# Altium schematic sheet Keyfinder_Alert.SchDoc
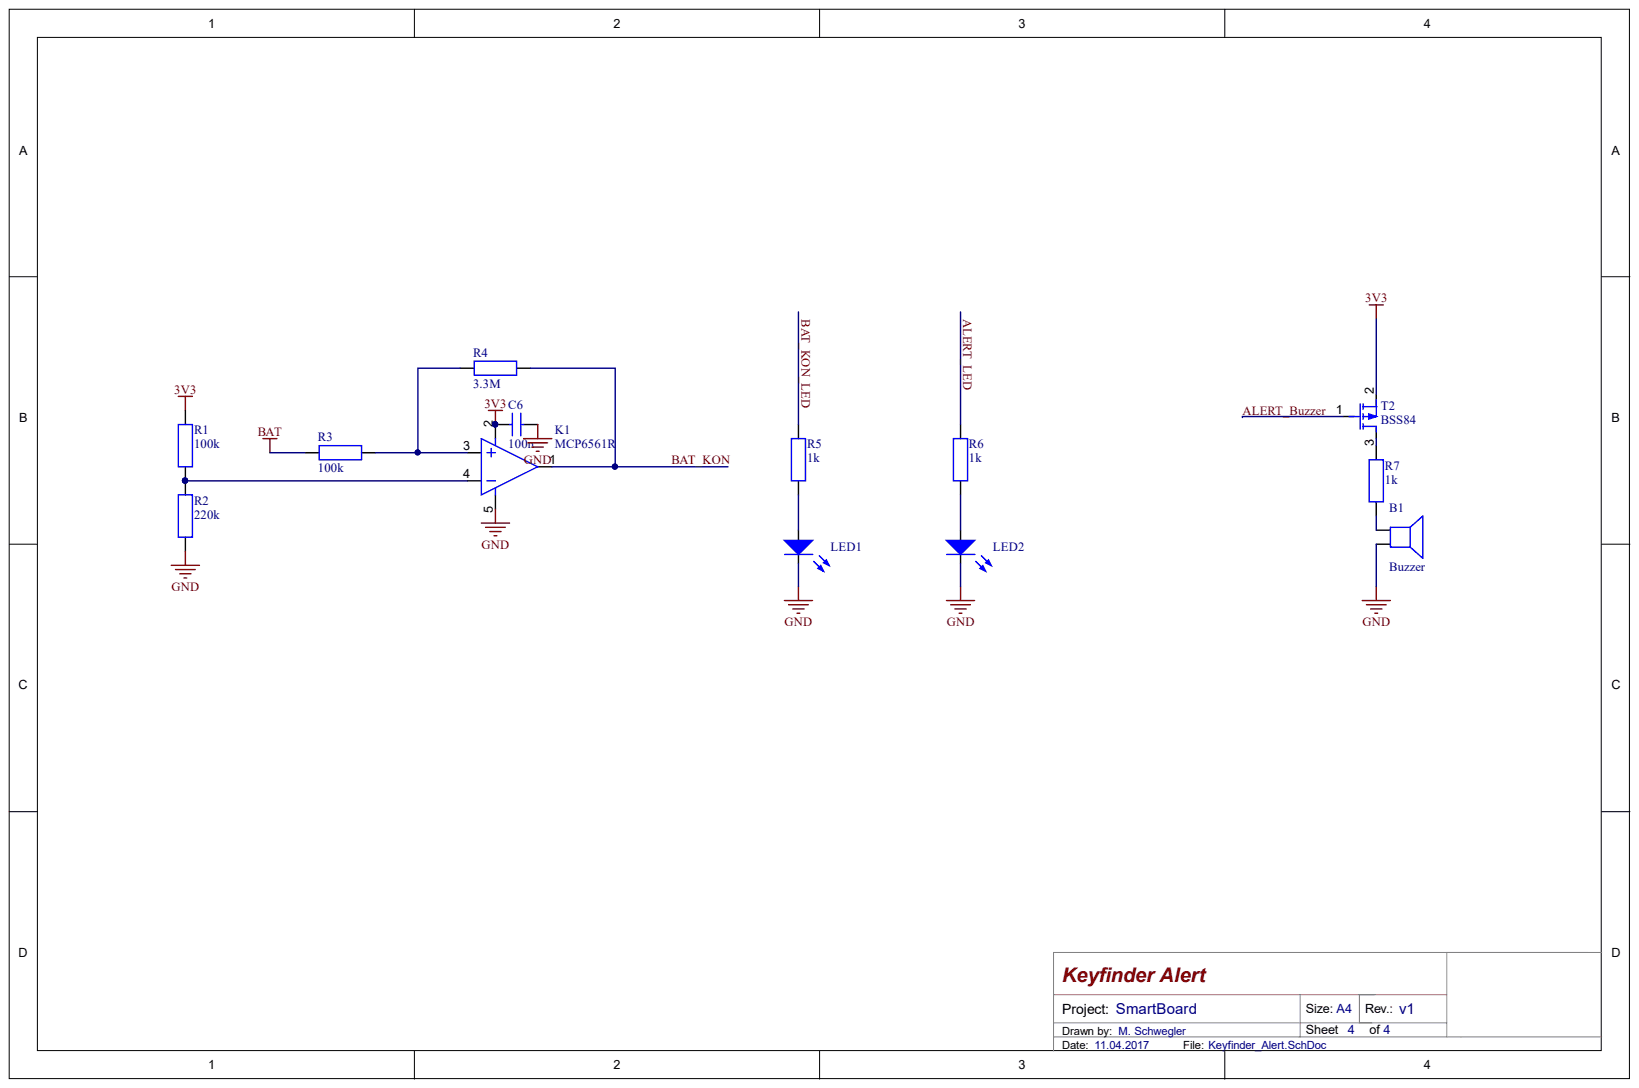
[diagram p0: Keyfinder_Alert.SchDoc]

## Parts
B1  Buzzer  [piezo_ele]
C6  100n  [0805_C]
K1  MCP6561R  [SOT23-5]
LED1  Value  [0805_LED]  (value not drawn)
LED2  Value  [0805_LED]  (value not drawn)
R1  100k  [0805_R]
R2  220k  [0805_R]
R3  100k  [0805_R]
R4  3.3M  [0805_R]
R5  1k  [0805_R]
R6  1k  [0805_R]
R7  1k  [0805_R]
T2  BSS84  [SOT23-3]

## Nets
3V3: C6.2 K1.2 R1.1 T2.2
BAT: R3.2
GND: B1.2 C6.1 K1.5 LED1.2 LED2.2 R2.2
ALERT_BUZZER: T2.1
ALERT_LED: R6.1
BAT_KON: K1.1 R4.1
BAT_KON_LED: R5.1
NetB1_1: B1.1 R7.2
NetK1_3: K1.3 R3.1 R4.2
NetK1_4: K1.4 R1.2 R2.1
NetLED1_1: LED1.1 R5.2
NetLED2_1: LED2.1 R6.2
NetR7_1: R7.1 T2.3

## Library data of the parts
B1 = SPEAKER: Component Kind: Standard; Library Name: DEVICE_HSLU.SchLib
C6 = C?: Normaler Kondensator; Component Kind: Standard; Library Name: MainBoard.SCHLIB
K1 = OPAMP: Component Kind: Standard; Library Name: MainBoard.SCHLIB
LED1 LED2 = LED: Component Kind: Standard; Library Name: Sch_Lib.SchLib
R1-R7 = R?: Normaler Widerstand; Component Kind: Standard; Library Name: MainBoard.SCHLIB
T2 = MOSFET-P 1D,2G,3S: Component Kind: Standard; Description: MOSFET-P 1D,2G,3S; Library Name: Sch_Lib.SchLib
